# Altium schematic sheet JacdacTopologyHubEc30 126.SchDoc
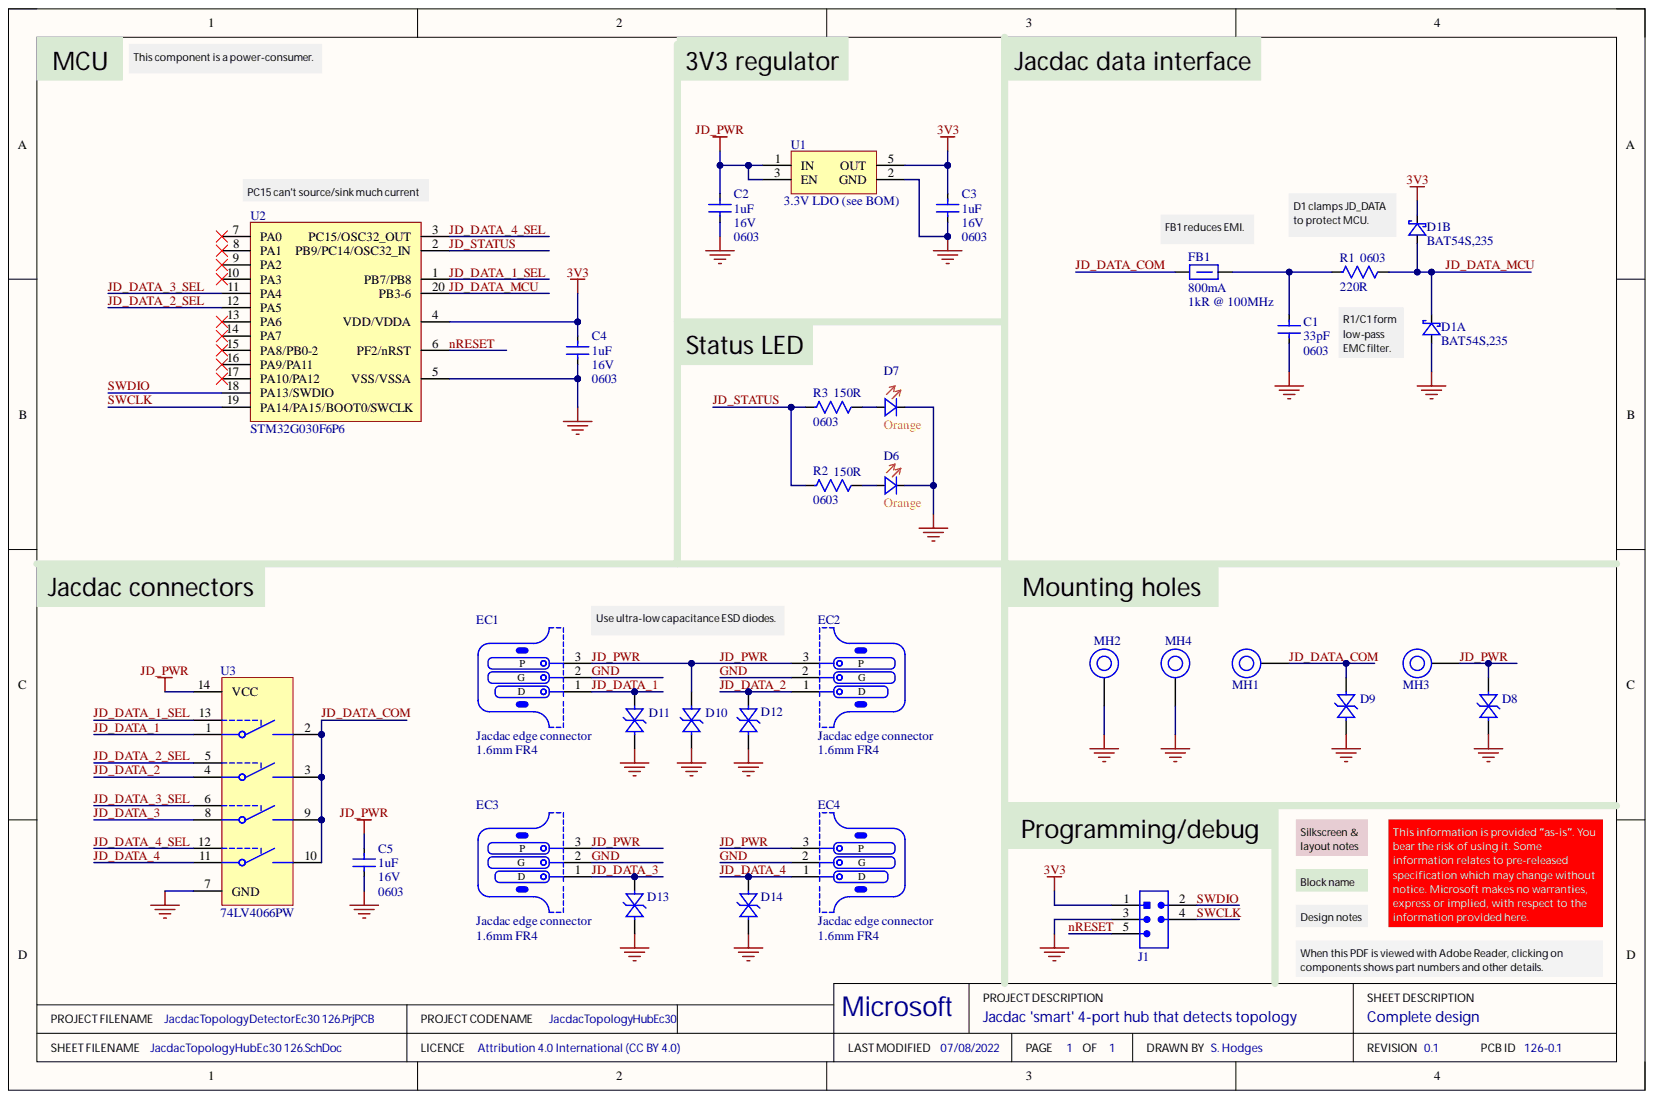
[diagram p0: JacdacTopologyHubEc30 126.SchDoc]

## Parts
C1  Capacitance: 33pF  Case Code (Imperial): 0603
C2  Capacitance: 1uF  Case Code (Imperial): 0603  Voltage: 16V
C3  Capacitance: 1uF  Case Code (Imperial): 0603  Voltage: 16V
C4  Capacitance: 1uF  Case Code (Imperial): 0603  Voltage: 16V
C5  Capacitance: 1uF  Case Code (Imperial): 0603  Voltage: 16V
D1  Manufacturer Part Number: BAT54S,235  (parts A,B)
D6  Color: Orange
D7  Color: Orange
D8  (no value)
D9  (no value)
D10  (no value)
D11  (no value)
D12  (no value)
D13  (no value)
D14  (no value)
EC1  PCB Type: 1.6mm FR4
EC2  PCB Type: 1.6mm FR4
EC3  PCB Type: 1.6mm FR4
EC4  PCB Type: 1.6mm FR4
FB1  Current Rating: 800mA
J1  (no value)
MH1  (no value)
MH2  (no value)
MH3  (no value)
MH4  (no value)
R1  Case Code (Imperial): 0603  Resistance: 220R
R2  Case Code (Imperial): 0603  Resistance: 150R
R3  Case Code (Imperial): 0603  Resistance: 150R
U1  Min Output Voltage: 3.3V
U2  Manufacturer Part Number 1: STM32G030F6P6
U3  Manufacturer Part Number 1: 74LV4066PW

## Nets
3V3: C3.2 C4.2 D1.2 J1.1 U1.5(OUT) U2.4(VDD/VDDA)
GND: C1.1 C2.1 C3.1 C4.1 C5.1 D1.1 D6.1 D7.1 D8.2 D9.2 D10.2 D11.2 D12.2 D13.2 D14.2 EC1.2 EC2.2 EC3.2 EC4.2 J1.3 MH2.1 MH4.1 U1.2(GND) U2.5(VSS/VSSA) U3.7(GND)
JD_DATA_1: D11.1 EC1.1 U3.1
JD_DATA_1_SEL: U2.1(PB7/PB8) U3.13
JD_DATA_2: D12.1 EC2.1 U3.4
JD_DATA_2_SEL: U2.12(PA5) U3.5
JD_DATA_3: D13.1 EC3.1 U3.8
JD_DATA_3_SEL: U2.11(PA4) U3.6
JD_DATA_4: D14.1 EC4.1 U3.11
JD_DATA_4_SEL: U2.3(PC15/OSC32_OUT) U3.12
JD_DATA_COM: D9.1 FB1.1 MH1.1 U3.2 U3.3 U3.9 U3.10
JD_DATA_MCU: D1.3 R1.2 U2.20(PB3-6)
JD_PWR: C2.2 C5.2 D8.1 D10.1 EC1.3 EC2.3 EC3.3 EC4.3 MH3.1 U1.1(IN) U1.3(EN) U3.14(VCC)
JD_STATUS: R2.1 R3.1 U2.2(PB9/PC14/OSC32_IN)
nRESET: J1.5 U2.6(PF2/nRST)
SWCLK: J1.4 U2.19(PA14/PA15/BOOT0/SWCLK)
SWDIO: J1.2 U2.18(PA13/SWDIO)
NetC1_2: C1.2 FB1.2 R1.1
NetD6_2: D6.2 R2.2
NetD7_2: D7.2 R3.2

Not connected: U2 7(PA0) 8(PA1) 9(PA2) 10(PA3) 13(PA6) 14(PA7) 15(PA8/PB0-2) 16(PA9/PA11) 17(PA10/PA12)

## Library data of the parts
C1 = (no symbol name): Cap 33PF 50V 0603 SMD 5% NP0; Manufacturer: Yageo; Manufacturer Part Number: CC0603JRNPO9BN330; Dielectric: C0G; Dielectric Material: Ceramic; Insulation Resistance: 100GR; Temperature Coefficient: 30ppm/°C; Case Code (Metric): 1608; Case/Package: 0603; Category: Ceramic Capacitors; ComponentLink1Description: https://datasheet.ciiva.com/11786/0900766b8079c870-11786082.pdf?src-supplier=RSComponents; ComponentLink1URL: https://datasheet.ciiva.com/11786/0900766b8079c870-11786082.pdf?src-supplier=RSComponents; ComponentLink2Description: Online part number coding; ComponentLink2URL: https://datasheet.ciiva.com/144/mlccorderingcode-144075.pdf?src-supplier=Digi-Key; ComponentLink3Description: Online datasheet; ComponentLink3URL: https://datasheet.ciiva.com/17434/upy-gphc-x7r-6-3v-to-50v-8-17434263.pdf; ComponentLink4Description: Online datasheet; ComponentLink4URL: https://datasheet.ciiva.com/26829/getdatasheetpartid-403577-26829431.pdf; ComponentLink5Description: https://datasheet.ciiva.com/26862/getdatasheetpartid-403532-26862767.pdf?src-supplier=Verical; ComponentLink5URL: https://datasheet.ciiva.com/26862/getdatasheetpartid-403532-26862767.pdf?src-supplier=Verical; ComponentLink6Description: https://datasheet.ciiva.com/8033/1443161-8033735.pdf?src-supplier=Element14; ComponentLink6URL: https://datasheet.ciiva.com/8033/1443161-8033735.pdf?src-supplier=Element14; ComponentLink7Description: https://datasheet.ciiva.com/7978/1443161-7978142.pdf?src-supplier=Element14; ComponentLink7URL: https://datasheet.ciiva.com/7978/1443161-7978142.pdf?src-supplier=Element14; ComponentLink8Description: https://datasheet.ciiva.com/46430/1835847-46430155.pdf?src-supplier=Element14; ComponentLink8URL: https://datasheet.ciiva.com/46430/1835847-46430155.pdf?src-supplier=Element14; ComponentLink9Description: https://datasheet.ciiva.com/144/mlccorderingcode-144644.pdf?src-supplier=Digi-Key; ComponentLink9URL: https://datasheet.ciiva.com/144/mlccorderingcode-144644.pdf?src-supplier=Digi-Key; ComponentLink10Description: https://datasheet.ciiva.com/144/mlccorderingcode-144643.pdf?src-supplier=Digi-Key; ComponentLink10URL: https://datasheet.ciiva.com/144/mlccorderingcode-144643.pdf?src-supplier=Digi-Key; ComponentLink11Description: https://datasheet.ciiva.com/144/mlccorderingcode-144645.pdf?src-supplier=Digi-Key; ComponentLink11URL: https://datasheet.ciiva.com/144/mlccorderingcode-144645.pdf?src-supplier=Digi-Key; ComponentLink12Description: https://datasheet.ciiva.com/5426/upy-gp-np0-16v-to-50v-8-5426614.pdf?src-supplier=Mouser; ComponentLink12URL: https://datasheet.ciiva.com/5426/upy-gp-np0-16v-to-50v-8-5426614.pdf?src-supplier=Mouser; ComponentLink13Description: https://datasheet.ciiva.com/8033/1372269-8033734.pdf?src-supplier=Element14; ComponentLink13URL: https://datasheet.ciiva.com/8033/1372269-8033734.pdf?src-supplier=Element14; ComponentLink14Description: https://datasheet.ciiva.com/11464/upy-gp-np0-16v-to-50v-8-11464730.pdf?src-supplier=Avnet; ComponentLink14URL: https://datasheet.ciiva.com/11464/upy-gp-np0-16v-to-50v-8-11464730.pdf?src-supplier=Avnet; Manufacturer 1: Yageo; Manufacturer Part Number 1: CC0603JRNPO9BN330; Max Operating Temperature: 125°C; Min Operating Temperature: -55°C; Number of Pins: 2; Resistor Type: Ceramic; RoHS Compliant: Yes; Series: CC; Supplier 1: Digi-Key; Supplier 2: Farnell; Supplier 3: RSComponents; Supplier 4: Mouser; Supplier 5: LCSC; Supplier Part Number 1: 311-1064-2-ND; Supplier Part Number 2: 2408499; Supplier Part Number 3: 2006492; Supplier Part Number 4: 603-C0603JRNPO9BN330; Supplier Part Number 5: C107047; Termination: SMD/SMT; Tolerance: 5%; Voltage: 50V; Voltage Rating: 50V; Voltage Rating (DC): 50V
C2-C5 = (no symbol name): SMD Multilayer Ceramic Capacitor, 1 µF, 16 V, 0603 [1608 Metric], ± 10%, X5R, CL Series; Manufacturer: Samsung; Manufacturer Part Number: CL10A105KO8NNNC; Case Code (Metric): 1608; Case/Package: 0603; Category: Ceramic Capacitors; ComponentLink1Description: Online datasheet; ComponentLink1URL: https://datasheet.lcsc.com/lcsc/1811021622_Samsung-Electro-Mechanics-CL10A105KO8NNNC_C1592.pdfq; Construction: Flat; Contact Plating: Tin; Dielectric: X5R; Manufacturer 1: Samsung; Manufacturer Part Number 1: CL10A105KO8NNNC; Material: Ceramic; Max Operating Temperature: 85°C; Min Operating Temperature: -55°C; RoHS Compliant: Yes; Series: CL; Supplier 1: Digi-Key; Supplier 2: Farnell; Supplier 3: RSComponents; Supplier 4: Mouser; Supplier 5: LCSC; Supplier Part Number 1: 1276-1034-1-ND; Supplier Part Number 2: 3013384; Supplier Part Number 3: 7664780; Supplier Part Number 4: 187-CL10A105KO8NNNC; Supplier Part Number 5: C1592; Tolerance: 10%; Voltage Rating: 16V; Voltage Rating (DC): 16V
D1 = (no symbol name): DIODE ARRAY SCHOTTKY 30V SOT23; Manufacturer: Nexperia; Category: Rectifier Diodes; ComponentLink1Description: NXP datasheet online; ComponentLink1URL: https://assets.nexperia.com/documents/data-sheet/BAT54_SER.pdf; Supplier 1: Digi-Key; Supplier 2: Mouser; Supplier 3: RSComponents; Supplier Part Number 1: 1727-1868-1-ND; Supplier Part Number 2: 771-BAT54S235; Supplier Part Number 3: 1707990
D6 D7 = (no symbol name): LED ORANGE DIFFUSED 0603 SMD; Manufacturer: Everlight Elec; Manufacturer Part Number: 19-217/S2C-AQ1R1B/3T; Case Code (Imperial): 0603; Case/Package: 0603; Colour: Orange; ComponentLink1Description: Online datasheet; ComponentLink1URL: https://datasheet.lcsc.com/lcsc/1912171731_Everlight-Elec-19-217-S2C-AQ1R1B-3T_C146215.pdf; Manufacturer 1: Everlight Elec; Manufacturer Part Number 1: 19-217/S2C-AQ1R1B/3T; Supplier 1: LCSC; Supplier Part Number 1: C146215
D8-D11 = (no symbol name): Bidirectional 5V (Max) 6V 16V 3.5A (8/20us) 0402 TVS RoHS; Manufacturer: Born Semiconductor; Manufacturer Part Number: RCLAMP0521P-N; ComponentLink1Description: Online datasheet; ComponentLink1URL: https://datasheet.lcsc.com/szlcsc/Bourne-Semicon-Shenzhen-RCLAMP0521P-N_C316043.pdf; Manufacturer 1: Born Semiconductor; Manufacturer Part Number 1: RCLAMP0521P-N; Package: 0402; Supplier 1: LCSC; Supplier Part Number 1: C316043
D12-D14 = (no symbol name): Bidirectional 5V (Max) 6V 16V 3.5A (8/20us) 0402 TVS RoHS; Manufacturer: Born Semiconductor; Manufacturer Part Number: RCLAMP0521 (see BOM); ComponentLink1Description: Online datasheet; ComponentLink1URL: https://datasheet.lcsc.com/szlcsc/Bourne-Semicon-Shenzhen-RCLAMP0521P-N_C316043.pdf; Manufacturer 1: Born Semiconductor; Manufacturer Part Number 1: RCLAMP0521 (see BOM); Package: 0402; Supplier 1: LCSC; Supplier Part Number 1: C316043
EC1-EC4 = (no symbol name): 3 pin Jacdac edge connector 1.27mm pitch; ComponentLink1Description: Yuliang JACDAC cable connector drawing online; ComponentLink1URL: https://github.com/microsoft/jacdac-ddk/tree/main/connector; ComponentLink2Description: JACDAC PCB edge connector spec onlin; ComponentLink2URL: https://github.com/microsoft/jacdac-ddk/tree/main/connector; Manufacturer Part Number: n/a
FB1 = (no symbol name): 0603 1k@100MHz 0.8A chip; Manufacturer: TDK; Manufacturer Part Number: MPZ1608S102ATA00; Core Material: Ferrite; DC Resistance (DCR): 300mR; Impedance: 1kR @ 100MHz; Tolerance: 25%; Case Code (Imperial): 0603; Case Code (Metric): 1608; Case/Package: 0603; ComponentLink2Description: datasheet online; ComponentLink2URL: https://www.mouser.co.uk/datasheet/2/400/eads_commercial_power_mpz1608_en-923650.pdf; ComponentLink3Description: datasheet; Manufacturer 1: TDK; Manufacturer Part Number 1: MPZ1608S102ATA00; Max DC Current: 800mA; Supplier 1: Digi-Key; Supplier 2: Mouser; Supplier Part Number 1: 445-8694-1-ND; Supplier Part Number 2: 810-MPZ1608S102ATA00; ComponentLink3URL: Y:\System\Altium\Libraries 2014\TDK\Datasheets\eads_commercial_power_mpz1608_en-923650.pdf
J1 = (no symbol name): Hack Connect Mini 1.27 mm pitch 2-row
MH1-MH4 = (no symbol name): Mounting Hole 6.5mm keepout, 4.5mm annualar ring and 3.0mm Drill PTH
R1 = (no symbol name): Res Thick Film 0603 220 Ohm 1% 0.1W(1/10W) ±100ppm/°C Pad SMD T/R; Max Power Dissipation: 100mW; Voltage Rating: 50V; Case Code (Metric): 1608; Case/Package: 0603; Category: Chip SMD Resistors; ComponentLink4Description: online datasheet; ComponentLink4URL: https://datasheet.ciiva.com/46395/443971-46395002.pdf; Construction: Rectangular; Features: Moisture Resistant; Manufacturer: = Manufacturer 1; Manufacturer 1: ="Manufacturer"; Manufacturer Part Number: = Manufacturer Part Number 1; Manufacturer Part Number 1: ="Manufacturer Part Number"; Max Operating Temperature: 155°C; Min Operating Temperature: -55°C; Number of Pins: 2; Number of Terminations: 2; Power Rating: 100mW; Resistance Tolerance: 1%; Resistor Type: Thick Film; RoHS Compliant: Yes; Series: RC; Size Code: 0603; Supplier 1: Digi-Key; Supplier 2: Farnell; Supplier 3: Mouser; Supplier 4: RSComponents; Supplier Part Number 1: 311-220HRCT-ND; Supplier Part Number 2: 2421863; Supplier Part Number 3: 603-RC0603FR-07220RL; Supplier Part Number 4: 1995497; Temperature Coefficient: 100ppm/°C; Termination: SMD/SMT; Tolerance: 1%; Voltage Rating (DC): 50V
R2 R3 = (no symbol name): Res General Purpose Thick Film 0603 150 Ohm 1% 1/10W ±100ppm/°C Molded SMD Paper T/R; Manufacturer: Yageo; Manufacturer Part Number: RC0603FR-07150RL; Max Power Dissipation: 100mW; Voltage Rating: 50V; Case Code (Metric): 1608; Case/Package: 0603; Category: Chip SMD Resistors; ComponentLink1Description: Online datasheet; ComponentLink1URL: https://datasheet.ciiva.com/11819/0900766b80f96399-11819290.pdf; Construction: Rectangular; Features: Moisture Resistant; Manufacturer 1: Yageo; Manufacturer Part Number 1: RC0603FR-07150RL; Max Operating Temperature: 155°C; Min Operating Temperature: -55°C; Number of Pins: 2; Number of Terminations: 2; Power Rating: 100mW; Resistance Tolerance: 1%; Resistor Type: Thick Film; RoHS Compliant: Yes; Series: RC; Size Code: 0603; Supplier 1: Digi-Key; Supplier 2: Farnell; Supplier 3: RSComponents; Supplier 4: Mouser; Supplier 5: LCSC; Supplier Part Number 1: 311-150HRCT-ND; Supplier Part Number 2: 9238387; Supplier Part Number 3: 1995464; Supplier Part Number 4: 603-RC0603FR-07150RL; Supplier Part Number 5: C114608; Temperature Coefficient: 100ppm/°C; Termination: SMD/SMT; Tolerance: 1%; Voltage Rating (DC): 50V
U1 = (no symbol name): 6V 260mV @ 200mA(typ) - - Fixed 3.3V SOT-23-5 Linear Voltage Regulators (LDO) ROHS; Manufacturer: MICRONE(Nanjing Micro One Elec); Manufacturer Part Number: ME6211C33M5G-N; Dropout Voltage: 200mV; Max Input Voltage: 6; Number of Regulators: 1; Output Configuration: Positive; Output Current: 500mA; Case/Package: SOT-23-5; Category: Voltage Regulators - Linear; ComponentLink3Description: On Semi datasheet online; ComponentLink3URL: https://datasheet.lcsc.com/lcsc/1811131510_MICRONE-Nanjing-Micro-One-Elec-ME6211C33M5G-N_C82942.pdf; Manufacturer 1: MICRONE(Nanjing Micro One Elec); Manufacturer Part Number 1: ME6211C33M5G-N; Output Type: Fixed; Supplier 1: LCSC; Supplier Part Number 1: C82942
U2 = (no symbol name): ARM® Cortex®-M0+ series IC 64MHz 32KB 20-TSSOP; Manufacturer 1: STMicroelectronics; Category: Microcontrollers; ComponentLink1Description: Online datasheet; ComponentLink1URL: https://www.st.com/resource/en/datasheet/stm32g030f6.pdf; ComponentLink2Description: Online reference manual; ComponentLink2URL: https://www.st.com/resource/en/reference_manual/rm0454-stm32g0x0-advanced-armbased-32bit-mcus-stmicroelectronics.pdf; RoHS Compliant: Yes; Supplier 1: Digi-Key; Supplier 2: Farnell; Supplier 3: Mouser; Supplier 10: LCSC; Supplier Part Number 1: 497-STM32G030F6P6-ND; Supplier Part Number 2: 3294301; Supplier Part Number 3: 511-STM32G030F6P6; Supplier Part Number 10: C724040
U3 = (no symbol name): 74LV Series 3.3 V SMT CMOS Low On Resistance Quad Bilateral Switch - TSSOP-14; Manufacturer 1: Nexperia USA; ComponentLink1Description: Online datasheet; ComponentLink1URL: https://assets.nexperia.com/documents/data-sheet/74LV4066.pdf; Supplier 1: Digi-Key; Supplier Part Number 1: 1727-6665-1-ND
